# Altium schematic sheet [04] - SX1276.SchDoc("[04] - SX1276")
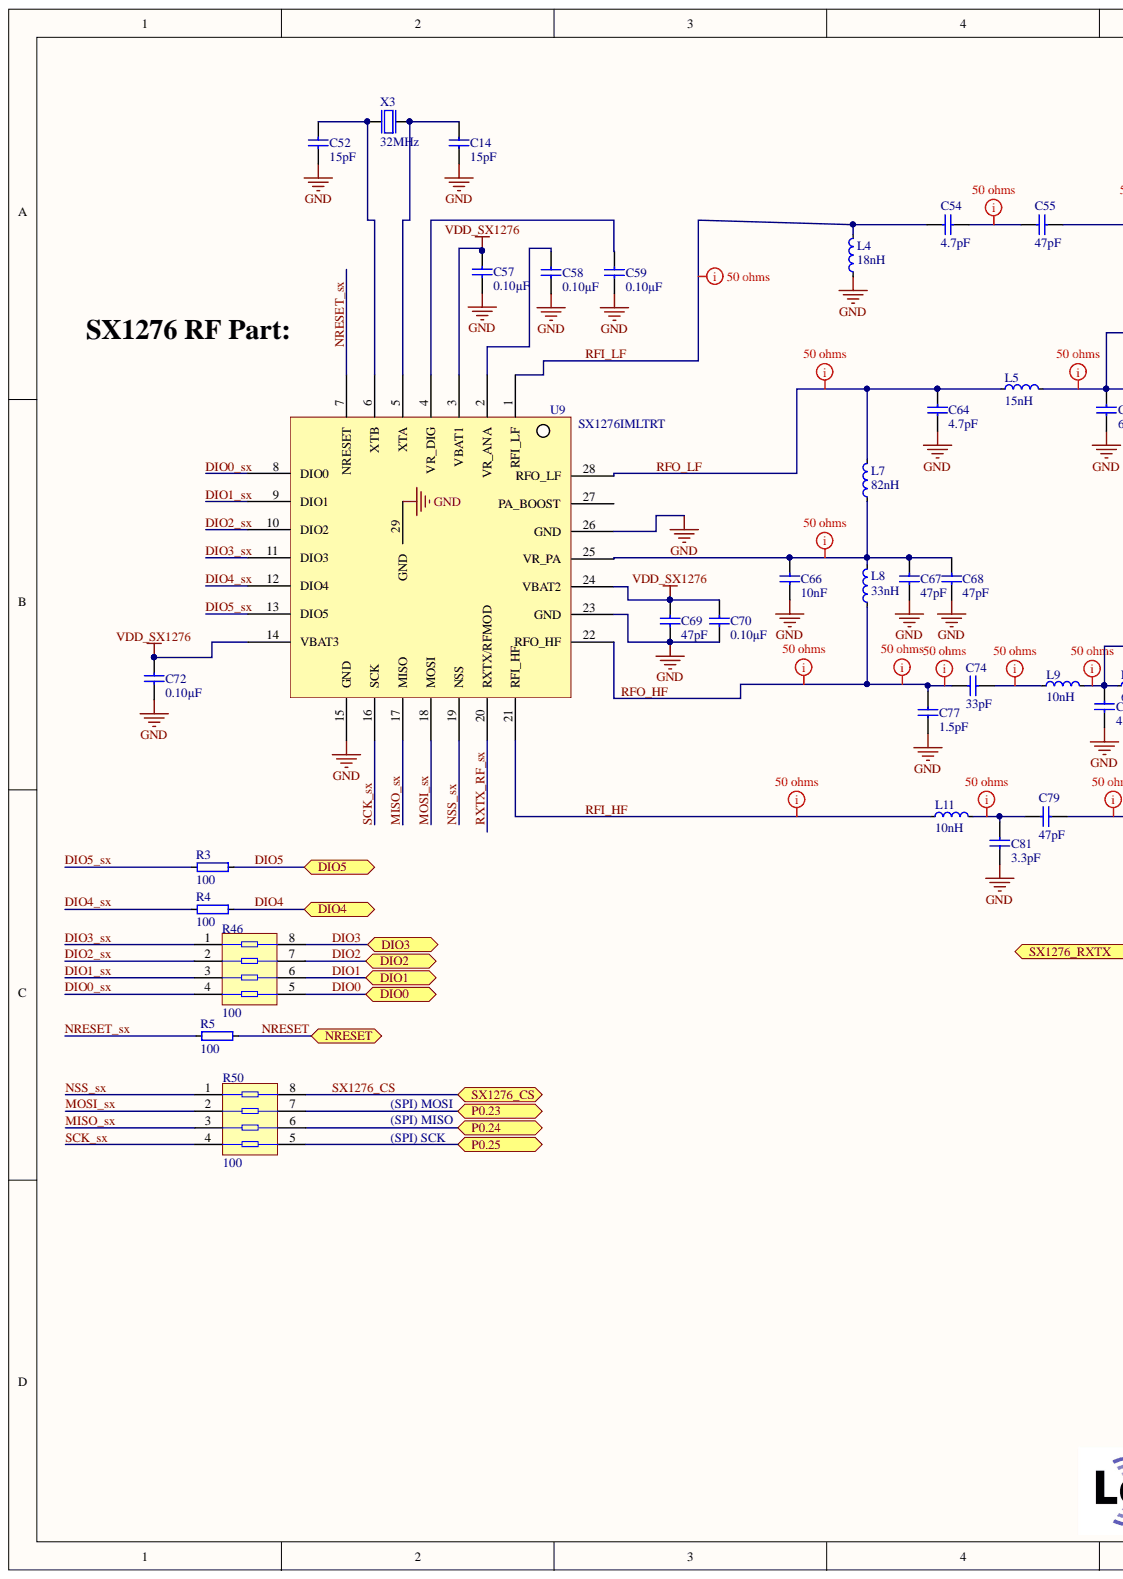
[diagram p0: [04] - SX1276.SchDoc, left]
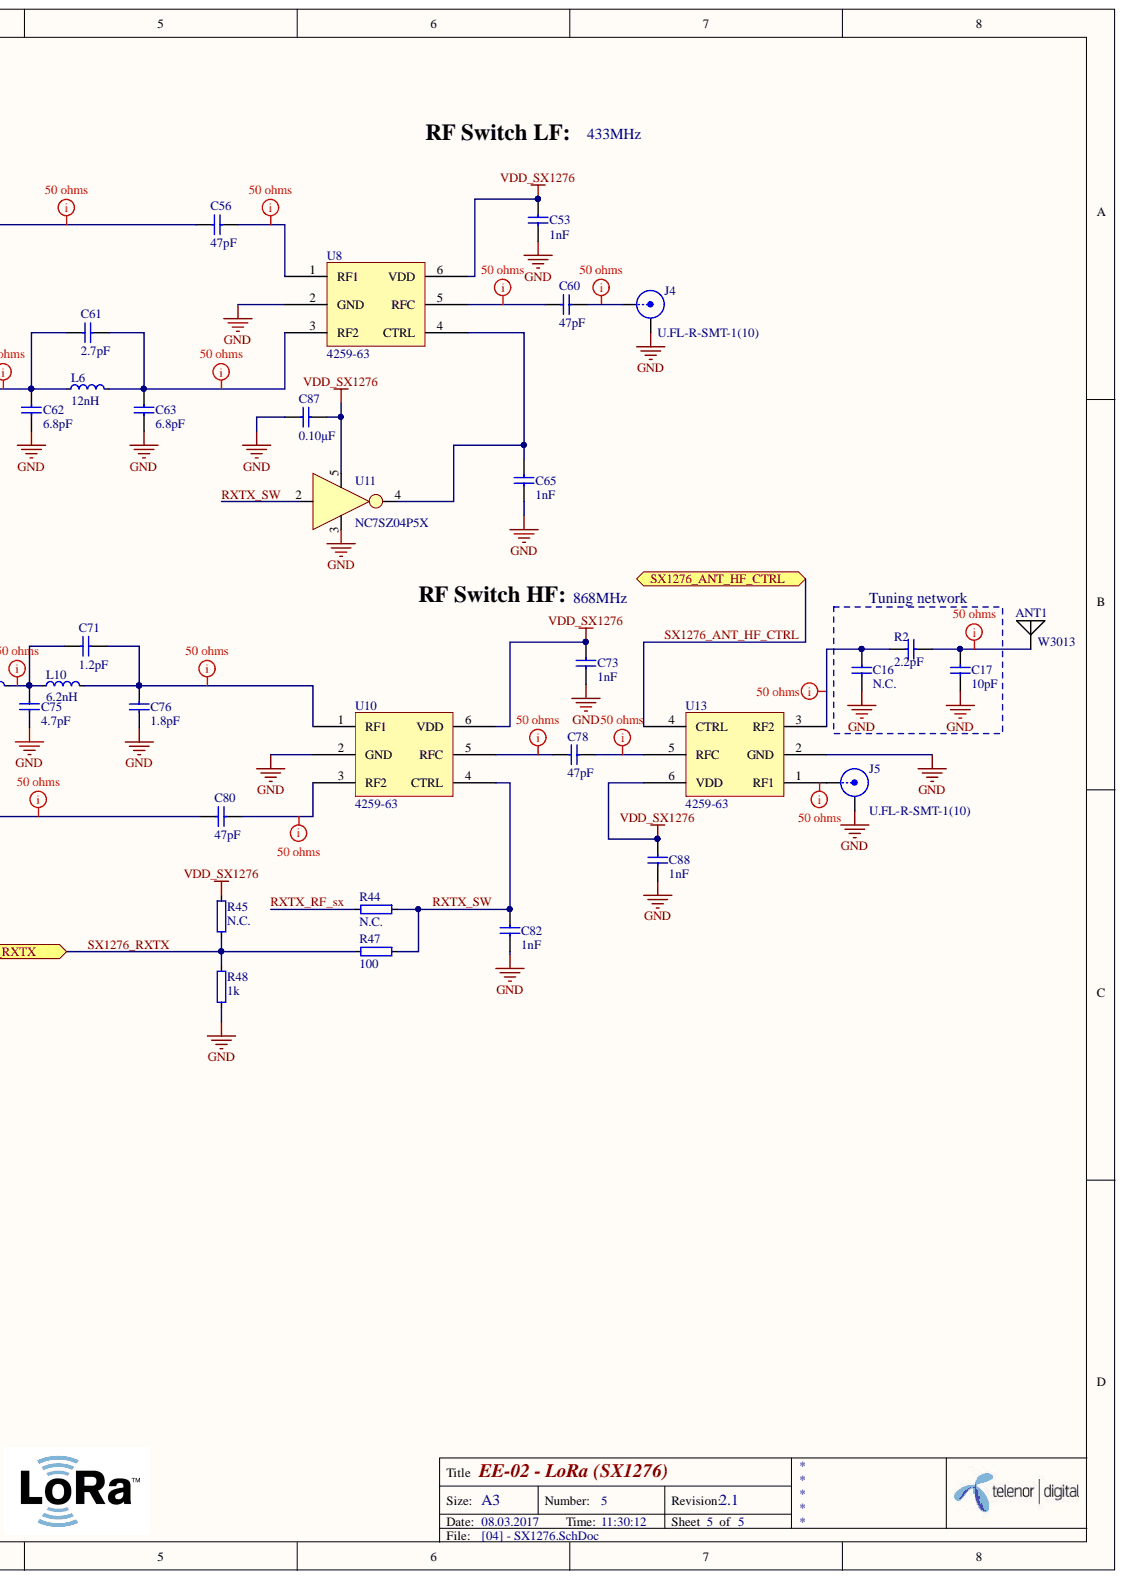
[diagram p1: [04] - SX1276.SchDoc, right]

## Parts
ANT1  W3013  [PULSE_W3013_R]
C14  15pF  [CAPC0603X03L]
C16  N.C.  [CAPC0603X03L]  (no BOM)
C17  10pF  [CAPC0603X03L]
C52  15pF  [CAPC0603X03L]
C53  1nF  [CAPC0603X03L]
C54  4.7pF  [CAPC0603X03L]
C55  47pF  [CAPC0603X03L]
C56  47pF  [CAPC0603X03L]
C57  0.10µF  [CAPC0603X03L]
C58  0.10µF  [CAPC0603X03L]
C59  0.10µF  [CAPC0603X03L]
C60  47pF  [CAPC0603X03L]
C61  2.7pF  [CAPC0603X03L]
C62  6.8pF  [CAPC0603X03L]
C63  6.8pF  [CAPC0603X03L]
C64  4.7pF  [CAPC0603X03L]
C65  1nF  [CAPC0603X03L]
C66  10nF  [CAPC0603X03L]
C67  47pF  [CAPC0603X03L]
C68  47pF  [CAPC0603X03L]
C69  47pF  [CAPC0603X03L]
C70  0.10µF  [CAPC0603X03L]
C71  1.2pF  [CAPC0603X03L]
C72  0.10µF  [CAPC0603X03L]
C73  1nF  [CAPC0603X03L]
C74  33pF  [CAPC0603X03L]
C75  4.7pF  [CAPC0603X03L]
C76  1.8pF  [CAPC0603X03L]
C77  1.5pF  [CAPC0603X03L]
C78  47pF  [CAPC0603X03L]
C79  47pF  [CAPC0603X03L]
C80  47pF  [CAPC0603X03L]
C81  3.3pF  [CAPC0603X03L]
C82  1nF  [CAPC0603X03L]
C87  0.10µF  [CAPC0603X03L]
C88  1nF  [CAPC0603X03L]
J4  U.FL-R-SMT-1(10)  [COAXIAL-UFL]
J5  U.FL-R-SMT-1(10)  [COAXIAL-UFL]
L4  18nH  [INDC1005X04L]
L5  15nH  [INDC1005X04L]
L6  12nH  [INDC1005X04L]
L7  82nH  [INDC1005X04L]
L8  33nH  [INDC1005X04L]
L9  10nH  [INDC1005X04L]
L10  6.2nH  [INDC1005X04L]
L11  10nH  [INDC1005X04L]
R2  2.2pF  [CAPC0603X03L]
R3  100  [RESC0603X03L]
R4  100  [RESC0603X03L]
R5  100  [RESC0603X03L]
R44  N.C.  [RESC0603X03LNC]
R45  N.C.  [RESC0603X03LNC]
R46  100  [EXB18V]
R47  100  [RESC0603X03L]
R48  1k  [RESC0603X03L]
R50  100  [EXB18V]
U8  4259-63  [SC70-6]
U9  SX1276IMLTRT  [vqfn28_6x6mm]
U10  4259-63  [SC70-6]
U11  NC7SZ04P5X  [SC70-5]
U13  4259-63  [SC70-6]
X3  32MHz  [nd2520da]

## Ports
DIO0, DIO1, DIO2, DIO3, DIO4, DIO5, NRESET, P0.23, P0.24, P0.25, SX1276_ANT_HF_CTRL, SX1276_CS, SX1276_RXTX

## Nets
GND: ANT1.2 ANT1.3 C14.1 C16.1 C17.1 C52.1 C53.1 C57.1 C58.1 C59.1 C62.1 C63.1 C64.1 C65.1 C66.1 C67.1 C68.1 C69.1 C70.1 C72.1 C73.1 C75.1 C76.1 C77.1 C81.1 C82.1 C87.1 C88.1 J4.2 J5.2 L4.1 R48.2 U8.2(GND) U9.15(GND) U9.23(GND) U9.26(GND) U9.29(GND) U10.2(GND) U11.3 U13.2(GND) X3.2 X3.4
VDD_SX1276: C53.2 C57.2 C69.2 C70.2 C72.2 C73.2 C87.2 C88.2 R45.2 U8.6(VDD) U9.3(VBAT1) U9.14(VBAT3) U9.24(VBAT2) U10.6(VDD) U11.5 U13.6(VDD)
DIO0: R46.5 ; port DIO0
DIO0_sx: R46.4 U9.8(DIO0)
DIO1: R46.6 ; port DIO1
DIO1_sx: R46.3 U9.9(DIO1)
DIO2: R46.7 ; port DIO2
DIO2_sx: R46.2 U9.10(DIO2)
DIO3: R46.8 ; port DIO3
DIO3_sx: R46.1 U9.11(DIO3)
DIO4: R4.2 ; port DIO4
DIO4_sx: R4.1 U9.12(DIO4)
DIO5: R3.2 ; port DIO5
DIO5_sx: R3.1 U9.13(DIO5)
MISO_sx: R50.3 U9.17(MISO)
MOSI_sx: R50.2 U9.18(MOSI)
NRESET: R5.2 ; port NRESET
NRESET_sx: R5.1 U9.7(NRESET)
NSS_sx: R50.1 U9.19(NSS)
P0.23: R50.7 ; port P0.23
P0.24: R50.6 ; port P0.24
P0.25: R50.5 ; port P0.25
RFI_HF: L11.1 U9.21(RFI_HF)
RFI_LF: C54.1 L4.2 U9.1(RFI_LF)
RFO_HF: C74.2 C77.2 L8.1 U9.22(RFO_HF)
RFO_LF: C64.2 L5.1 L7.2 U9.28(RFO_LF)
RXTX_RF_sx: R44.1 U9.20(RXTX/RFMOD)
RXTX_SW: C82.2 R44.2 R47.2 U10.4(CTRL) U11.2
SCK_sx: R50.4 U9.16(SCK)
SX1276_ANT_HF_CTRL: U13.4(CTRL) ; port SX1276_ANT_HF_CTRL
SX1276_CS: R50.8 ; port SX1276_CS
SX1276_RXTX: R45.1 R47.1 R48.1 ; port SX1276_RXTX
NetANT1_1: ANT1.1 C17.2 R2.2
NetC14_2: C14.2 U9.5(XTA) X3.3
NetC16_2: C16.2 R2.1 U13.3(RF2)
NetC52_2: C52.2 U9.6(XTB) X3.1
NetC54_2: C54.2 C55.2
NetC55_1: C55.1 C56.2
NetC56_1: C56.1 U8.1(RF1)
NetC58_2: C58.2 U9.2(VR_ANA)
NetC59_2: C59.2 U9.4(VR_DIG)
NetC60_1: C60.1 J4.1
NetC60_2: C60.2 U8.5(RFC)
NetC61_1: C61.1 C62.2 L5.2 L6.1
NetC61_2: C61.2 C63.2 L6.2 U8.3(RF2)
NetC65_2: C65.2 U8.4(CTRL) U11.4
NetC66_2: C66.2 C67.2 C68.2 L7.1 L8.2 U9.25(VR_PA)
NetC71_1: C71.1 C75.2 L9.2 L10.1
NetC71_2: C71.2 C76.2 L10.2 U10.1(RF1)
NetC74_1: C74.1 L9.1
NetC78_1: C78.1 U13.5(RFC)
NetC78_2: C78.2 U10.5(RFC)
NetC79_1: C79.1 C80.2
NetC79_2: C79.2 C81.2 L11.2
NetC80_1: C80.1 U10.3(RF2)
NetJ5_1: J5.1 U13.1(RF1)
N00119:

Not connected: U9 27(PA_BOOST)

## Library data of the parts
ANT1 = W3013: ANTENNA CERAMIC ISM 868MHZ; Manufacturer: Pulse Electronics Corporation; Return Loss: -10dB; Number of Bands: 1; Gain: 1.4dBi; Frequency Range: 868MHz ~ 870MHz; Frequency Group: UHF (300 MHz ~ 1 GHz); Antenna Type: Chip; VSWR: -; Value: W3013; Termination: Solder; Supplier Part Number 1: 553-1677-6-ND; Supplier 1: Digi-Key; Stock 1: 2216; RoHS: RoHS Compliant; Pricing 1: 1=1.75, 10=1.584, 25=1.4192, 100=1.276, 250=1.133 (USD); Power - Max: -; Part Status: Active; Packaging: Digi-Reel®; Mounting Type: Surface Mount; Manufacturer Part Number: W3013; Ingress Protection: -; Height (Max): 0.157" (4.00mm); Frequency (Center/Band): 868MHz; Features: -; ComponentLink1URL: http://productfinder.pulseeng.com/products/datasheets/W3013.pdf; ComponentLink1Description: http://productfinder.pulseeng.com/products/datasheets/W3013.pdf; Component Kind: Standard; Category: RF/IF and RFID; Applications: -; Library Name: Skunkworks Library.IntLib
C14 C52 = GRM0335C1H150GA01D: CAP CER 15PF 50V NP0 0201; Manufacturer: Murata Electronics North America; Tolerance: ±2%; Temperature Coefficient: C0G, NP0; Voltage - Rated: 50V; Value: 15pF; Thickness (Max): 0.013" (0.33mm); Supplier Part Number 1: 490-11309-6-ND; Supplier 1: Digi-Key; Stock 1: 750; Size / Dimension: 0.024" L x 0.012" W (0.60mm x 0.30mm); RoHS: RoHS Compliant; Ratings: -; Pricing 1: 1=0.1, 10=0.014, 50=0.0078, 100=0.0066, 250=0.0054, 500=0.00462, 1000=0.0036, 5000=0.003 (USD); Part Status: Active; Packaging: Digi-Reel®; Package / Case: 0201 (0603 Metric); Operating Temperature: -55°C ~ 125°C; Mounting Type: Surface Mount, MLCC; Manufacturer Part Number: GRM0335C1H150GA01D; Lead Style: -; Lead Spacing: -; Height - Seated (Max): -; Features: -; ComponentLink1URL: http://www.murata.com/~/media/webrenewal/support/library/catalog/products/capacitor/mlcc/c02e.pdf; ComponentLink1Description: http://www.murata.com/~/media/webrenewal/support/library/catalog/products/capacitor/mlcc/c02e.pdf; Component Kind: Standard; Category: Capacitors; Capacitance: 15pF; Applications: General Purpose; Library Name: Skunkworks Library.IntLib
C16 = CAP_0201-NC: Capacitor, 0201, N.C.; Part Number: C0201-NC; Artikkelnr Simpro: N.A.; Value: N.C.; Published: 2016-11-04; Pin Count: 2; LatestRevisionDate: 2016-11-04; Footprint Doc: 0201; Artikkelnr Noca: N.A.; Publisher: Telenor Digital; Library Name: Skunkworks Library.IntLib
C17 = 250R05L100GV4T: CAP CER 10PF 25V NP0 0201; Manufacturer: Johanson Technology Inc.; Tolerance: ±2%; Temperature Coefficient: C0G, NP0; Voltage - Rated: 25V; Value: 10pF; Thickness (Max): 0.013" (0.33mm); Supplier Part Number 1: 712-1204-6-ND; Supplier 1: Digi-Key; Stock 1: 18246; Size / Dimension: 0.024" L x 0.012" W (0.60mm x 0.30mm); RoHS: RoHS Compliant; Ratings: -; Pricing 1: 1=0.23, 10=0.16, 25=0.1172, 50=0.0958, 100=0.0809, 250=0.06596, 500=0.05532, 1000=0.04894, 5000=0.04256 (USD); Part Status: Active; Packaging: Digi-Reel®; Package / Case: 0201 (0603 Metric); Operating Temperature: -55°C ~ 125°C; Mounting Type: Surface Mount, MLCC; Manufacturer Part Number: 250R05L100GV4T; Lead Style: -; Lead Spacing: -; Height - Seated (Max): -; Features: High Q, Low Loss; ComponentLink1URL: http://www.johansontechnology.com/downloads/JTI-CAT-MLCC-HighQ.pdf; ComponentLink1Description: http://www.johansontechnology.com/downloads/JTI-CAT-MLCC-HighQ.pdf; Component Kind: Standard; Category: Capacitors; Capacitance: 10pF; Applications: RF, Microwave, High Frequency; Library Name: Skunkworks Library.IntLib
C53 C65 C73 C82 C88 = GRM033R71H102KA12D: CAP CER 1NF 50V X7R 0201; Manufacturer: Murata Electronics North America; Tolerance: ±10%; Voltage - Rated: 50V; Value: 1nF; Thickness (Max): 0.013" (0.33mm); Temperature Coefficient: X7R; Supplier Part Number 1: 490-10682-6-ND; Supplier 1: Digi-Key; Stock 1: 132650; Size / Dimension: 0.024" L x 0.012" W (0.60mm x 0.30mm); RoHS: RoHS Compliant; Ratings: -; Pricing 1: 1=0.1, 10=0.012, 50=0.0066, 100=0.0055, 250=0.00452, 500=0.00386, 1000=0.003, 5000=0.0025 (USD); Part Status: Active; Packaging: Digi-Reel®; Package / Case: 0201 (0603 Metric); Operating Temperature: -55°C ~ 125°C; Mounting Type: Surface Mount, MLCC; Manufacturer Part Number: GRM033R71H102KA12D; Lead Style: -; Lead Spacing: -; Height - Seated (Max): -; Features: -; ComponentLink1URL: http://www.murata.com/~/media/webrenewal/support/library/catalog/products/capacitor/mlcc/c02e.pdf; ComponentLink1Description: http://www.murata.com/~/media/webrenewal/support/library/catalog/products/capacitor/mlcc/c02e.pdf; Component Kind: Standard; Category: Capacitors; Capacitance: 1nF; Applications: General Purpose; Library Name: Skunkworks Library.IntLib
C54 C64 C75 = GRM0335C1H4R7BA01D: CAP CER 4.7PF 50V NP0 0201; Manufacturer: Murata Electronics North America; Tolerance: ±0.1pF; Temperature Coefficient: C0G, NP0; Voltage - Rated: 50V; Value: 4.7pF; Thickness (Max): 0.013" (0.33mm); Supplier Part Number 1: 490-11332-6-ND; Supplier 1: Digi-Key; Stock 1: 70150; Size / Dimension: 0.024" L x 0.012" W (0.60mm x 0.30mm); RoHS: RoHS Compliant; Ratings: -; Pricing 1: 1=0.1, 10=0.011, 50=0.0058, 100=0.005, 250=0.00404, 500=0.00346, 1000=0.0027, 5000=0.00225 (USD); Part Status: Active; Packaging: Digi-Reel®; Package / Case: 0201 (0603 Metric); Operating Temperature: -55°C ~ 125°C; Mounting Type: Surface Mount, MLCC; Manufacturer Part Number: GRM0335C1H4R7BA01D; Lead Style: -; Lead Spacing: -; Height - Seated (Max): -; Features: -; ComponentLink1URL: http://www.murata.com/~/media/webrenewal/support/library/catalog/products/capacitor/mlcc/c02e.pdf; ComponentLink1Description: http://www.murata.com/~/media/webrenewal/support/library/catalog/products/capacitor/mlcc/c02e.pdf; Component Kind: Standard; Category: Capacitors; Capacitance: 4.7pF; Applications: General Purpose; Library Name: Skunkworks Library.IntLib
C55 C56 C60 C67-C69 C78-C80 = GRM0335C1H470JA01D: CAP CER 47PF 50V NP0 0201; Manufacturer: Murata Electronics North America; Tolerance: ±5%; Temperature Coefficient: C0G, NP0; Voltage - Rated: 50V; Value: 47pF; Supplier Part Number 1: 490-6129-6-ND; Supplier 1: Digi-Key; Stock 1: 174645; Size / Dimension: 0.024" L x 0.012" W (0.60mm x 0.30mm); RoHS: RoHS Compliant; Ratings: -; Pricing 1: 1=0.1, 10=0.011, 50=0.0058, 100=0.005, 250=0.00404, 500=0.00346, 1000=0.0027, 5000=0.00225 (USD); Part Status: Active; Packaging: Digi-Reel®; Package / Case: 0201 (0603 Metric); Operating Temperature: -55°C ~ 125°C; Mounting Type: Surface Mount, MLCC; Manufacturer Part Number: GRM0335C1H470JA01D; Lead Style: -; Lead Spacing: -; Height - Seated (Max): -; Features: -; ComponentLink1URL: http://www.murata.com/~/media/webrenewal/support/library/catalog/products/capacitor/mlcc/c02e.pdf; ComponentLink1Description: http://www.murata.com/~/media/webrenewal/support/library/catalog/products/capacitor/mlcc/c02e.pdf; Component Kind: Standard; Category: Capacitors; Capacitance: 47pF; Applications: General Purpose; Library Name: Skunkworks Library.IntLib
C57-C59 C70 C72 C87 = GRM033R61C104KE84D: CAP CER 0.1UF 16V X5R 0201; Manufacturer: Murata Electronics North America; Tolerance: ±10%; Voltage - Rated: 16V; Value: 0.10µF; Thickness (Max): 0.013" (0.33mm); Temperature Coefficient: X5R; Supplier Part Number 1: 490-9985-6-ND; Supplier 1: Digi-Key; Stock 1: 0; Size / Dimension: 0.024" L x 0.012" W (0.60mm x 0.30mm); RoHS: RoHS Compliant; Ratings: -; Pricing 1: 1=0.1, 10=0.025, 50=0.0136, 100=0.0116, 250=0.00944, 500=0.00808, 1000=0.0063, 5000=0.00525 (USD); Part Status: Active; Packaging: Digi-Reel®; Package / Case: 0201 (0603 Metric); Operating Temperature: -55°C ~ 85°C; Mounting Type: Surface Mount, MLCC; Manufacturer Part Number: GRM033R61C104KE84D; Lead Style: -; Lead Spacing: -; Height - Seated (Max): -; Features: Derating Recommended; ComponentLink1URL: http://www.murata.com/~/media/webrenewal/support/library/catalog/products/capacitor/mlcc/c02e.pdf; ComponentLink1Description: http://www.murata.com/~/media/webrenewal/support/library/catalog/products/capacitor/mlcc/c02e.pdf; Component Kind: Standard; Category: Capacitors; Capacitance: 0.10µF; Applications: General Purpose; Library Name: Skunkworks Library.IntLib
C61 = GRM0335C1H2R7BA01D: CAP CER 2.7PF 50V NP0 0201; Manufacturer: Murata Electronics North America; Tolerance: ±0.1pF; Temperature Coefficient: C0G, NP0; Voltage - Rated: 50V; Value: 2.7pF; Thickness (Max): 0.013" (0.33mm); Supplier Part Number 1: 490-11322-6-ND; Supplier 1: Digi-Key; Stock 1: 26213; Size / Dimension: 0.024" L x 0.012" W (0.60mm x 0.30mm); RoHS: RoHS Compliant; Ratings: -; Pricing 1: 1=0.1, 10=0.014, 50=0.0078, 100=0.0066, 250=0.0054, 500=0.00462, 1000=0.0036, 5000=0.003 (USD); Part Status: Active; Packaging: Digi-Reel®; Package / Case: 0201 (0603 Metric); Operating Temperature: -55°C ~ 125°C; Mounting Type: Surface Mount, MLCC; Manufacturer Part Number: GRM0335C1H2R7BA01D; Lead Style: -; Lead Spacing: -; Height - Seated (Max): -; Features: -; ComponentLink1URL: http://www.murata.com/~/media/webrenewal/support/library/catalog/products/capacitor/mlcc/c02e.pdf; ComponentLink1Description: http://www.murata.com/~/media/webrenewal/support/library/catalog/products/capacitor/mlcc/c02e.pdf; Component Kind: Standard; Category: Capacitors; Capacitance: 2.7pF; Applications: General Purpose; Library Name: Skunkworks Library.IntLib
C62 C63 = GRM0335C1H6R8CA01D: CAP CER 6.8PF 50V NP0 0201; Manufacturer: Murata Electronics North America; Tolerance: ±0.25pF; Temperature Coefficient: C0G, NP0; Voltage - Rated: 50V; Value: 6.8pF; Thickness (Max): 0.013" (0.33mm); Supplier Part Number 1: 490-11341-6-ND; Supplier 1: Digi-Key; Stock 1: 184512; Size / Dimension: 0.024" L x 0.012" W (0.60mm x 0.30mm); RoHS: RoHS Compliant; Ratings: -; Pricing 1: 1=0.1, 10=0.011, 50=0.0058, 100=0.005, 250=0.00404, 500=0.00346, 1000=0.0027, 5000=0.00225 (USD); Part Status: Active; Packaging: Digi-Reel®; Package / Case: 0201 (0603 Metric); Operating Temperature: -55°C ~ 125°C; Mounting Type: Surface Mount, MLCC; Manufacturer Part Number: GRM0335C1H6R8CA01D; Lead Style: -; Lead Spacing: -; Height - Seated (Max): -; Features: -; ComponentLink1URL: http://www.murata.com/~/media/webrenewal/support/library/catalog/products/capacitor/mlcc/c02e.pdf; ComponentLink1Description: http://www.murata.com/~/media/webrenewal/support/library/catalog/products/capacitor/mlcc/c02e.pdf; Component Kind: Standard; Category: Capacitors; Capacitance: 6.8pF; Applications: General Purpose; Library Name: Skunkworks Library.IntLib
C66 = GRM033R61E103KA12D: CAP CER 10NF 25V X5R 0201; Manufacturer: Murata Electronics North America; Tolerance: ±10%; Voltage - Rated: 25V; Value: 10nF; Thickness (Max): 0.013" (0.33mm); Temperature Coefficient: X5R; Supplier Part Number 1: 490-7194-6-ND; Supplier 1: Digi-Key; Stock 1: 1209439; Size / Dimension: 0.024" L x 0.012" W (0.60mm x 0.30mm); RoHS: RoHS Compliant; Ratings: -; Pricing 1: 1=0.1, 10=0.012, 50=0.0066, 100=0.0055, 250=0.00452, 500=0.00386, 1000=0.003, 5000=0.0025 (USD); Part Status: Active; Packaging: Digi-Reel®; Package / Case: 0201 (0603 Metric); Operating Temperature: -55°C ~ 85°C; Mounting Type: Surface Mount, MLCC; Manufacturer Part Number: GRM033R61E103KA12D; Lead Style: -; Lead Spacing: -; Height - Seated (Max): -; Features: Derating Recommended; ComponentLink1URL: http://www.murata.com/~/media/webrenewal/support/library/catalog/products/capacitor/mlcc/c02e.pdf; ComponentLink1Description: http://www.murata.com/~/media/webrenewal/support/library/catalog/products/capacitor/mlcc/c02e.pdf; Component Kind: Standard; Category: Capacitors; Capacitance: 10nF; Applications: General Purpose; Library Name: Skunkworks Library.IntLib
C71 = GRM0335C1H1R2BA01D: CAP CER 1.2PF 50V NP0 0201; Manufacturer: Murata Electronics North America; Tolerance: ±0.1pF; Temperature Coefficient: C0G, NP0; Voltage - Rated: 50V; Value: 1.2pF; Thickness (Max): 0.013" (0.33mm); Supplier Part Number 1: 490-9661-6-ND; Supplier 1: Digi-Key; Stock 1: 29460; Size / Dimension: 0.024" L x 0.012" W (0.60mm x 0.30mm); RoHS: RoHS Compliant; Ratings: -; Pricing 1: 1=0.1, 10=0.014, 50=0.0078, 100=0.0066, 250=0.0054, 500=0.00462, 1000=0.0036, 5000=0.003 (USD); Part Status: Active; Packaging: Digi-Reel®; Package / Case: 0201 (0603 Metric); Operating Temperature: -55°C ~ 125°C; Mounting Type: Surface Mount, MLCC; Manufacturer Part Number: GRM0335C1H1R2BA01D; Lead Style: -; Lead Spacing: -; Height - Seated (Max): -; Features: -; ComponentLink1URL: http://www.murata.com/~/media/webrenewal/support/library/catalog/products/capacitor/mlcc/c02e.pdf; ComponentLink1Description: http://www.murata.com/~/media/webrenewal/support/library/catalog/products/capacitor/mlcc/c02e.pdf; Component Kind: Standard; Category: Capacitors; Capacitance: 1.2pF; Applications: General Purpose; Library Name: Skunkworks Library.IntLib
C74 = GRM0335C1H330GA01D: CAP CER 33PF 50V NP0 0201; Manufacturer: Murata Electronics North America; Tolerance: ±2%; Temperature Coefficient: C0G, NP0; Voltage - Rated: 50V; Value: 33pF; Thickness (Max): 0.013" (0.33mm); Supplier Part Number 1: 490-11325-6-ND; Supplier 1: Digi-Key; Stock 1: 48655; Size / Dimension: 0.024" L x 0.012" W (0.60mm x 0.30mm); RoHS: RoHS Compliant; Ratings: -; Pricing 1: 1=0.1, 10=0.014, 50=0.0078, 100=0.0066, 250=0.0054, 500=0.00462, 1000=0.0036, 5000=0.003 (USD); Part Status: Active; Packaging: Digi-Reel®; Package / Case: 0201 (0603 Metric); Operating Temperature: -55°C ~ 125°C; Mounting Type: Surface Mount, MLCC; Manufacturer Part Number: GRM0335C1H330GA01D; Lead Style: -; Lead Spacing: -; Height - Seated (Max): -; Features: -; ComponentLink1URL: http://www.murata.com/~/media/webrenewal/support/library/catalog/products/capacitor/mlcc/c02e.pdf; ComponentLink1Description: http://www.murata.com/~/media/webrenewal/support/library/catalog/products/capacitor/mlcc/c02e.pdf; Component Kind: Standard; Category: Capacitors; Capacitance: 33pF; Applications: General Purpose; Library Name: Skunkworks Library.IntLib
C76 = GRM0335C1H1R8BA01D: CAP CER 1.8PF 50V NP0 0201; Manufacturer: Murata Electronics North America; Tolerance: ±0.1pF; Temperature Coefficient: C0G, NP0; Voltage - Rated: 50V; Value: 1.8pF; Thickness (Max): 0.013" (0.33mm); Supplier Part Number 1: 490-11313-6-ND; Supplier 1: Digi-Key; Stock 1: 20450; Size / Dimension: 0.024" L x 0.012" W (0.60mm x 0.30mm); RoHS: RoHS Compliant; Ratings: -; Pricing 1: 1=0.1, 10=0.014, 50=0.0078, 100=0.0066, 250=0.0054, 500=0.00462, 1000=0.0036, 5000=0.003 (USD); Part Status: Active; Packaging: Digi-Reel®; Package / Case: 0201 (0603 Metric); Operating Temperature: -55°C ~ 125°C; Mounting Type: Surface Mount, MLCC; Manufacturer Part Number: GRM0335C1H1R8BA01D; Lead Style: -; Lead Spacing: -; Height - Seated (Max): -; Features: -; ComponentLink1URL: http://www.murata.com/~/media/webrenewal/support/library/catalog/products/capacitor/mlcc/c02e.pdf; ComponentLink1Description: http://www.murata.com/~/media/webrenewal/support/library/catalog/products/capacitor/mlcc/c02e.pdf; Component Kind: Standard; Category: Capacitors; Capacitance: 1.8pF; Applications: General Purpose; Library Name: Skunkworks Library.IntLib
C77 = GRM0335C1H1R5BA01D: CAP CER 1.5PF 50V NP0 0201; Manufacturer: Murata Electronics North America; Tolerance: ±0.1pF; Temperature Coefficient: C0G, NP0; Voltage - Rated: 50V; Value: 1.5pF; Thickness (Max): 0.013" (0.33mm); Supplier Part Number 1: 490-11311-6-ND; Supplier 1: Digi-Key; Stock 1: 40910; Size / Dimension: 0.024" L x 0.012" W (0.60mm x 0.30mm); RoHS: RoHS Compliant; Ratings: -; Pricing 1: 1=0.1, 10=0.014, 50=0.0078, 100=0.0066, 250=0.0054, 500=0.00462, 1000=0.0036, 5000=0.003 (USD); Part Status: Active; Packaging: Digi-Reel®; Package / Case: 0201 (0603 Metric); Operating Temperature: -55°C ~ 125°C; Mounting Type: Surface Mount, MLCC; Manufacturer Part Number: GRM0335C1H1R5BA01D; Lead Style: -; Lead Spacing: -; Height - Seated (Max): -; Features: -; ComponentLink1URL: http://www.murata.com/~/media/webrenewal/support/library/catalog/products/capacitor/mlcc/c02e.pdf; ComponentLink1Description: http://www.murata.com/~/media/webrenewal/support/library/catalog/products/capacitor/mlcc/c02e.pdf; Component Kind: Standard; Category: Capacitors; Capacitance: 1.5pF; Applications: General Purpose; Library Name: Skunkworks Library.IntLib
C81 = GRM0335C1H3R3BA01D: CAP CER 3.3PF 50V NP0 0201; Manufacturer: Murata Electronics North America; Tolerance: ±0.1pF; Temperature Coefficient: C0G, NP0; Voltage - Rated: 50V; Value: 3.3pF; Thickness (Max): 0.013" (0.33mm); Supplier Part Number 1: 490-11328-6-ND; Supplier 1: Digi-Key; Stock 1: 48664; Size / Dimension: 0.024" L x 0.012" W (0.60mm x 0.30mm); RoHS: RoHS Compliant; Ratings: -; Pricing 1: 1=0.1, 10=0.014, 50=0.0078, 100=0.0066, 250=0.0054, 500=0.00462, 1000=0.0036, 5000=0.003 (USD); Part Status: Active; Packaging: Digi-Reel®; Package / Case: 0201 (0603 Metric); Operating Temperature: -55°C ~ 125°C; Mounting Type: Surface Mount, MLCC; Manufacturer Part Number: GRM0335C1H3R3BA01D; Lead Style: -; Lead Spacing: -; Height - Seated (Max): -; Features: -; ComponentLink1URL: http://www.murata.com/~/media/webrenewal/support/library/catalog/products/capacitor/mlcc/c02e.pdf; ComponentLink1Description: http://www.murata.com/~/media/webrenewal/support/library/catalog/products/capacitor/mlcc/c02e.pdf; Component Kind: Standard; Category: Capacitors; Capacitance: 3.3pF; Applications: General Purpose; Library Name: Skunkworks Library.IntLib
J4 J5 = U.FL-R-SMT-1(10): CONN UMC JACK STR 50 OHM SMD; Manufacturer: Hirose Electric Co Ltd; Shield Termination: Solder; Impedance: 50 Ohm; Frequency - Max: 6GHz; Dielectric Material: Liquid Crystal Polymer (LCP); Connector Type: Jack, Male Pin; Connector Style: U.FL; Center Contact Plating: Gold; Center Contact Material: Phosphor Bronze; Body Finish: Silver; Voltage Rating: -; Supplier Part Number 1: H11891DKR-ND; Supplier 1: Digi-Key; Stock 1: 124618; RoHS: RoHS Compliant; Pricing 1: 1=1.15, 10=1.013, 25=0.9532, 50=0.9138, 100=0.8739, 250=0.79448, 500=0.71504, 1000=0.63559 (EUR); Packaging: Digi-Reel®; Operating Temperature: -40°C ~ 90°C; Mounting Type: Surface Mount; Manufacturer Part Number: U.FL-R-SMT-1(10); Ingress Protection: -; Housing Color: Silver; Features: -; Fastening Type: Snap-On; Contact Termination: Solder; ComponentLink1URL: http://media.digikey.com/pdf/Data%20Sheets/Hirose%20PDFs/UFL%20Series.pdf; ComponentLink1Description: http://media.digikey.com/pdf/Data%20Sheets/Hirose%20PDFs/UFL%20Series.pdf; Component Kind: Standard; Category: Connectors, Interconnects; Cable Group: -; Body Material: Phosphor Bronze; Library Name: Skunkworks Library.IntLib
L4 = LQG15HS18NJ02D: FIXED IND 18NH 300MA 360 MOHM; Manufacturer: Murata Electronics North America; Type: Multilayer; Tolerance: ±5%; Shielding: Unshielded; Q @ Freq: 8 @ 100MHz; Material - Core: Air; Frequency - Test: 100MHz; Frequency - Self Resonant: 2.2GHz; DC Resistance (DCR): 360 mOhm Max; Value: 18nH; Supplier Part Number 1: 490-2626-6-ND; Supplier 1: Digi-Key; Stock 1: 165090; Size / Dimension: 0.039" L x 0.020" W (1.00mm x 0.50mm); RoHS: RoHS Compliant; Ratings: -; Pricing 1: 1=0.09, 10=0.052, 25=0.0464, 50=0.0402, 100=0.0361, 250=0.03352, 500=0.03092, 750=0.02937, 1000=0.02679 (EUR); Packaging: Digi-Reel®; Package / Case: 0402 (1005 Metric); Operating Temperature: -55°C ~ 125°C; Mounting Type: Surface Mount; Manufacturer Part Number: LQG15HS18NJ02D; Inductance: 18nH; Height - Seated (Max): 0.022" (0.55mm); Current Rating: 300mA; Current - Saturation: -; ComponentLink1URL: http://search.murata.co.jp/Ceramy/image/img/PDF/ENG/L0075S0083LQG15HS.pdf; ComponentLink1Description: http://search.murata.co.jp/Ceramy/image/img/PDF/ENG/L0075S0083LQG15HS.pdf; Component Kind: Standard; Category: Inductors, Coils, Chokes; Library Name: Skunkworks Library.IntLib
L5 = LQG15HS15NJ02D: FIXED IND 15NH 300MA 320 MOHM; Manufacturer: Murata Electronics North America; Type: Multilayer; Tolerance: ±5%; Shielding: Unshielded; Q @ Freq: 8 @ 100MHz; Material - Core: Air; Frequency - Test: 100MHz; Frequency - Self Resonant: 2.5GHz; DC Resistance (DCR): 320 mOhm Max; Value: 15nH; Supplier Part Number 1: 490-2625-6-ND; Supplier 1: Digi-Key; Stock 1: 47142; Size / Dimension: 0.039" L x 0.020" W (1.00mm x 0.50mm); RoHS: RoHS Compliant; Ratings: -; Pricing 1: 1=0.09, 10=0.052, 25=0.0464, 50=0.0402, 100=0.0361, 250=0.03352, 500=0.03092, 750=0.02937, 1000=0.02679 (EUR); Packaging: Digi-Reel®; Package / Case: 0402 (1005 Metric); Operating Temperature: -55°C ~ 125°C; Mounting Type: Surface Mount; Manufacturer Part Number: LQG15HS15NJ02D; Inductance: 15nH; Height - Seated (Max): 0.022" (0.55mm); Current Rating: 300mA; Current - Saturation: -; ComponentLink1URL: http://search.murata.co.jp/Ceramy/image/img/PDF/ENG/L0075S0083LQG15HS.pdf; ComponentLink1Description: http://search.murata.co.jp/Ceramy/image/img/PDF/ENG/L0075S0083LQG15HS.pdf; Component Kind: Standard; Category: Inductors, Coils, Chokes; Library Name: Skunkworks Library.IntLib
L6 = LQG15HS12NJ02D: FIXED IND 12NH 300MA 280 MOHM; Manufacturer: Murata Electronics North America; Type: Multilayer; Tolerance: ±5%; Shielding: Unshielded; Q @ Freq: 8 @ 100MHz; Material - Core: Air; Frequency - Test: 100MHz; Frequency - Self Resonant: 3GHz; DC Resistance (DCR): 280 mOhm Max; Value: 12nH; Supplier Part Number 1: 490-2624-6-ND; Supplier 1: Digi-Key; Stock 1: 77424; Size / Dimension: 0.039" L x 0.020" W (1.00mm x 0.50mm); RoHS: RoHS Compliant; Ratings: -; Pricing 1: 1=0.09, 10=0.052, 25=0.0464, 50=0.0402, 100=0.0361, 250=0.03352, 500=0.03092, 750=0.02937, 1000=0.02679 (EUR); Packaging: Digi-Reel®; Package / Case: 0402 (1005 Metric); Operating Temperature: -55°C ~ 125°C; Mounting Type: Surface Mount; Manufacturer Part Number: LQG15HS12NJ02D; Inductance: 12nH; Height - Seated (Max): 0.022" (0.55mm); Current Rating: 300mA; Current - Saturation: -; ComponentLink1URL: http://search.murata.co.jp/Ceramy/image/img/PDF/ENG/L0075S0083LQG15HS.pdf; ComponentLink1Description: http://search.murata.co.jp/Ceramy/image/img/PDF/ENG/L0075S0083LQG15HS.pdf; Component Kind: Standard; Category: Inductors, Coils, Chokes; Library Name: Skunkworks Library.IntLib
L7 = LQG15HS82NJ02D: FIXED IND 82NH 150MA 1.2 OHM SMD; Manufacturer: Murata Electronics North America; Type: Multilayer; Tolerance: ±5%; Shielding: Unshielded; Q @ Freq: 8 @ 100MHz; Material - Core: Air; Frequency - Test: 100MHz; Frequency - Self Resonant: 700MHz; DC Resistance (DCR): 1.2 Ohm Max; Value: 82nH; Supplier Part Number 1: 490-2634-6-ND; Supplier 1: Digi-Key; Stock 1: 28103; Size / Dimension: 0.039" L x 0.020" W (1.00mm x 0.50mm); RoHS: RoHS Compliant; Ratings: -; Pricing 1: 1=0.09, 10=0.061, 25=0.0548, 50=0.0474, 100=0.0425, 250=0.03948, 500=0.03646, 750=0.03464, 1000=0.0316 (EUR); Packaging: Digi-Reel®; Package / Case: 0402 (1005 Metric); Operating Temperature: -55°C ~ 125°C; Mounting Type: Surface Mount; Manufacturer Part Number: LQG15HS82NJ02D; Inductance: 82nH; Height - Seated (Max): 0.022" (0.55mm); Current Rating: 150mA; Current - Saturation: -; ComponentLink1URL: http://search.murata.co.jp/Ceramy/image/img/PDF/ENG/L0075S0083LQG15HS.pdf; ComponentLink1Description: http://search.murata.co.jp/Ceramy/image/img/PDF/ENG/L0075S0083LQG15HS.pdf; Component Kind: Standard; Category: Inductors, Coils, Chokes; Library Name: Skunkworks Library.IntLib
L8 = LQW15AN33NJ00D: FIXED IND 33NH 260MA 630 MOHM; Manufacturer: Murata Electronics North America; Type: Wirewound; Tolerance: ±5%; Shielding: Unshielded; Q @ Freq: 25 @ 250MHz; Material - Core: Non-Magnetic; Frequency - Test: 100MHz; Frequency - Self Resonant: 3.2GHz; DC Resistance (DCR): 630 mOhm Max; Value: 33nH; Supplier Part Number 1: 490-1152-6-ND; Supplier 1: Digi-Key; Stock 1: 51716; Size / Dimension: 0.039" L x 0.020" W (1.00mm x 0.50mm); RoHS: RoHS Compliant; Ratings: -; Pricing 1: 1=0.15, 10=0.132, 25=0.1192, 50=0.103, 100=0.0925, 250=0.08588, 500=0.07928, 750=0.07532, 1000=0.0687 (EUR); Packaging: Digi-Reel®; Package / Case: 0402 (1005 Metric); Operating Temperature: -55°C ~ 125°C; Mounting Type: Surface Mount; Manufacturer Part Number: LQW15AN33NJ00D; Inductance: 33nH; Height - Seated (Max): 0.024" (0.60mm); Current Rating: 260mA; Current - Saturation: -; ComponentLink1URL: http://search.murata.co.jp/Ceramy/image/img/PDF/ENG/L0075S0080LQW15A_00.pdf; ComponentLink1Description: http://search.murata.co.jp/Ceramy/image/img/PDF/ENG/L0075S0080LQW15A_00.pdf; Component Kind: Standard; Category: Inductors, Coils, Chokes; Library Name: Skunkworks Library.IntLib
L9 L11 = LQG15HS10NJ02D: FIXED IND 10NH 300MA 260 MOHM; Manufacturer: Murata Electronics North America; Type: Multilayer; Tolerance: ±5%; Shielding: Unshielded; Q @ Freq: 8 @ 100MHz; Material - Core: Air; Frequency - Test: 100MHz; Frequency - Self Resonant: 3.4GHz; DC Resistance (DCR): 260 mOhm Max; Value: 10nH; Supplier Part Number 1: 490-2623-6-ND; Supplier 1: Digi-Key; Stock 1: 110764; Size / Dimension: 0.039" L x 0.020" W (1.00mm x 0.50mm); RoHS: RoHS Compliant; Ratings: -; Pricing 1: 1=0.09, 10=0.052, 25=0.0464, 50=0.0402, 100=0.0361, 250=0.03352, 500=0.03092, 750=0.02937, 1000=0.02679 (EUR); Packaging: Digi-Reel®; Package / Case: 0402 (1005 Metric); Operating Temperature: -55°C ~ 125°C; Mounting Type: Surface Mount; Manufacturer Part Number: LQG15HS10NJ02D; Inductance: 10nH; Height - Seated (Max): 0.022" (0.55mm); Current Rating: 300mA; Current - Saturation: -; ComponentLink1URL: http://search.murata.co.jp/Ceramy/image/img/PDF/ENG/L0075S0083LQG15HS.pdf; ComponentLink1Description: http://search.murata.co.jp/Ceramy/image/img/PDF/ENG/L0075S0083LQG15HS.pdf; Component Kind: Standard; Category: Inductors, Coils, Chokes; Library Name: Skunkworks Library.IntLib
L10 = LQG15HS6N2S02D: FIXED IND 6.2NH 300MA 220 MOHM; Manufacturer: Murata Electronics North America; Type: Multilayer; Tolerance: ±0.3nH; Shielding: Unshielded; Q @ Freq: 8 @ 100MHz; Material - Core: Air; Frequency - Test: 100MHz; Frequency - Self Resonant: 4.5GHz; DC Resistance (DCR): 220 mOhm Max; Value: 6.2nH; Supplier Part Number 1: 490-2620-6-ND; Supplier 1: Digi-Key; Stock 1: 52721; Size / Dimension: 0.039" L x 0.020" W (1.00mm x 0.50mm); RoHS: RoHS Compliant; Ratings: -; Pricing 1: 1=0.09, 10=0.052, 25=0.0464, 50=0.0402, 100=0.0361, 250=0.03352, 500=0.03092, 750=0.02937, 1000=0.02679 (EUR); Packaging: Digi-Reel®; Package / Case: 0402 (1005 Metric); Operating Temperature: -55°C ~ 125°C; Mounting Type: Surface Mount; Manufacturer Part Number: LQG15HS6N2S02D; Inductance: 6.2nH; Height - Seated (Max): 0.022" (0.55mm); Current Rating: 300mA; Current - Saturation: -; ComponentLink1URL: http://search.murata.co.jp/Ceramy/image/img/PDF/ENG/L0075S0083LQG15HS.pdf; ComponentLink1Description: http://search.murata.co.jp/Ceramy/image/img/PDF/ENG/L0075S0083LQG15HS.pdf; Component Kind: Standard; Category: Inductors, Coils, Chokes; Library Name: Skunkworks Library.IntLib
R2 = 250R05L2R2BV4T: CAP CER 2.2PF 25V NP0 0201; Manufacturer: Johanson Technology Inc.; Tolerance: ±0.1pF; Temperature Coefficient: C0G, NP0; Voltage - Rated: 25V; Value: 2.2pF; Thickness (Max): 0.013" (0.33mm); Supplier Part Number 1: 712-1188-6-ND; Supplier 1: Digi-Key; Stock 1: 75089; Size / Dimension: 0.024" L x 0.012" W (0.60mm x 0.30mm); RoHS: RoHS Compliant; Ratings: -; Pricing 1: 1=0.23, 10=0.16, 25=0.1172, 50=0.0958, 100=0.0809, 250=0.06596, 500=0.05532, 1000=0.04894, 5000=0.04256 (USD); Part Status: Active; Packaging: Digi-Reel®; Package / Case: 0201 (0603 Metric); Operating Temperature: -55°C ~ 125°C; Mounting Type: Surface Mount, MLCC; Manufacturer Part Number: 250R05L2R2BV4T; Lead Style: -; Lead Spacing: -; Height - Seated (Max): -; Features: High Q, Low Loss; ComponentLink1URL: http://www.johansontechnology.com/downloads/JTI-CAT-MLCC-HighQ.pdf; ComponentLink1Description: http://www.johansontechnology.com/downloads/JTI-CAT-MLCC-HighQ.pdf; Component Kind: Standard; Category: Capacitors; Capacitance: 2.2pF; Applications: RF, Microwave, High Frequency; Library Name: Skunkworks Library.IntLib
R3-R5 R47 = RC0201FR-07100RL: RES SMD 100 OHM 1% 1/20W 0201; Manufacturer: Yageo; Temperature Coefficient: ±200ppm/°C; Power (Watts): 0.05W, 1/20W; Value: 100; Tolerance: ±1%; Supplier Part Number 1: 311-100MDKR-ND; Supplier Device Package: 0201; Supplier 1: Digi-Key; Stock 1: 295829; Size / Dimension: 0.024" L x 0.012" W (0.60mm x 0.30mm); RoHS: RoHS Compliant; Resistance (Ohms): 100; Pricing 1: 1=0.1, 10=0.055, 25=0.0396, 100=0.0222, 250=0.01692, 500=0.01354, 1000=0.00997, 2500=0.00865, 5000=0.00715 (USD); Part Status: Active; Packaging: Digi-Reel®; Package / Case: 0201 (0603 Metric); Operating Temperature: -55°C ~ 125°C; Number of Terminations: 2; Manufacturer Part Number: RC0201FR-07100RL; Height - Seated (Max): 0.010" (0.26mm); Features: Moisture Resistant; Failure Rate: -; Composition: Thick Film; ComponentLink1URL: http://www.yageo.com/NewPortal/yageodocoutput?fileName=/pdf/R-Chip/PYu-RC_Group_51_RoHS_L_4.pdf; ComponentLink1Description: http://www.yageo.com/NewPortal/yageodocoutput?fileName=/pdf/R-Chip/PYu-RC_Group_51_RoHS_L_4.pdf; Component Kind: Standard; Category: Resistors; Library Name: Skunkworks Library.IntLib
R44 R45 = RES_0201: Not mounted; Part Number: R0201-N.C.; Note: Chip Res; Artikkelnr Simpro: N.A.; Value: N.C.; Published: 2009-05-08; Pin Count: 2; LatestRevisionDate: 2009-05-08; Footprint Doc: 0201; Component Kind: Standard; Artikkelnr Noca: N.A.; Publisher: Nordic Semiconductor; Library Name: Skunkworks Library.IntLib
R46 R50 = EXB-18V101JX: RES ARRAY 4 RES 100 OHM 0502; Manufacturer: Panasonic Electronic Components; Tolerance: ±5%; Temperature Coefficient: ±200ppm/°C; Power Per Element: 31mW; Number of Resistors: 4; Circuit Type: Isolated; Value: 100; Supplier Part Number 1: Y6101DKR-ND; Supplier Device Package: 0502; Supplier 1: Digi-Key; Stock 1: 27122; Size / Dimension: 0.055" L x 0.024" W (1.40mm x 0.60mm); RoHS: RoHS Compliant; Resistance (Ohms): 100; Pricing 1: 1=0.59, 50=0.2296, 100=0.1845, 250=0.14352, 500=0.10824, 1000=0.08364, 5000=0.06232 (USD); Part Status: Active; Packaging: Digi-Reel®; Package / Case: 0502 (1406 Metric), Long Side Terminals; Operating Temperature: -55°C ~ 125°C; Number of Pins: 8; Mounting Type: Surface Mount; Manufacturer Part Number: EXB-18V101JX; Height: 0.018" (0.45mm); ComponentLink1URL: https://industrial.panasonic.com/cdbs/www-data/pdf/AOC0000/AOC0000CE4.pdf; ComponentLink1Description: https://industrial.panasonic.com/cdbs/www-data/pdf/AOC0000/AOC0000CE4.pdf; Component Kind: Standard; Category: Resistors; Applications: -; Library Name: Skunkworks Library.IntLib
R48 = RC0201FR-071KL: RES SMD 1K OHM 1% 1/20W 0201; Manufacturer: Yageo; Temperature Coefficient: ±200ppm/°C; Power (Watts): 0.05W, 1/20W; Value: 1k; Tolerance: ±1%; Supplier Part Number 1: 311-1KMDKR-ND; Supplier Device Package: 0201; Supplier 1: Digi-Key; Stock 1: 243673; Size / Dimension: 0.024" L x 0.012" W (0.60mm x 0.30mm); RoHS: RoHS Compliant; Resistance (Ohms): 1k; Pricing 1: 1=0.1, 10=0.074, 25=0.054, 100=0.0303, 250=0.02312, 500=0.0185, 1000=0.01361, 2500=0.01182, 5000=0.00976 (USD); Part Status: Active; Packaging: Digi-Reel®; Package / Case: 0201 (0603 Metric); Operating Temperature: -55°C ~ 125°C; Number of Terminations: 2; Manufacturer Part Number: RC0201FR-071KL; Height - Seated (Max): 0.010" (0.26mm); Features: Moisture Resistant; Failure Rate: -; Composition: Thick Film; ComponentLink1URL: http://www.yageo.com/NewPortal/yageodocoutput?fileName=/pdf/R-Chip/PYu-RC_Group_51_RoHS_L_4.pdf; ComponentLink1Description: http://www.yageo.com/NewPortal/yageodocoutput?fileName=/pdf/R-Chip/PYu-RC_Group_51_RoHS_L_4.pdf; Component Kind: Standard; Category: Resistors; Library Name: Skunkworks Library.IntLib
U8 U10 U13 = 4259-63: IC RF SWITCH SPDT 50 OHM SC70-6; Manufacturer: Peregrine Semiconductor; Voltage - Supply: 1.8 V ~ 3.3 V; Topology: Reflective; RF Type: General Purpose; P1dB: 29dBm (typ) IP1dB; Isolation @ Frequency: 20dB @ 2GHz (typ); Insertion Loss @ Frequency: 0.5dB @ 2GHz; Impedance: 50 Ohm; IIP3: 55dBm (typ); Frequency - Upper: 3GHz; Frequency - Lower: 10MHz; Supplier Part Number 1: 1046-1011-6-ND; Supplier Device Package: SC-70-6; Supplier 1: Digi-Key; Stock 1: 190923; RoHS: RoHS Compliant; Pricing 1: 1=0.57, 101=0.45802, 1000=0.37874 (EUR); Packaging: Digi-Reel®; Package / Case: 6-TSSOP, SC-88, SOT-363; Operating Temperature: -40°C ~ 85°C; Manufacturer Part Number: 4259-63; Features: Single/Dual Line Control; ComponentLink1URL: http://www.psemi.com/pdf/datasheets/pe4259ds.pdf; ComponentLink1Description: http://www.psemi.com/pdf/datasheets/pe4259ds.pdf; Component Kind: Standard; Circuit: SPDT; Category: RF/IF and RFID; Library Name: Skunkworks Library.IntLib
U9 = SX1276IMLTRT: IC 137-1050MHX TXRX; Manufacturer: Semtech Corporation; Voltage - Supply: 1.8 V ~ 3.7 V; Serial Interfaces: SPI; Sensitivity: -137dBm; RF Family/Standard: General ISM < 1GHz; Power - Output: 20dBm; Modulation: FSK, GFSK, GMSK, LoRa™, MSK, OOK; Frequency: 137MHz ~ 1.02GHz; Data Rate (Max): 300kbps; Current - Transmitting: 28mA; Current - Receiving: 13mA; Type: TxRx Only; Supplier Part Number 1: SX1276IMLTRTDKR-ND; Supplier 1: Digi-Key; Stock 1: 8423; RoHS: RoHS Compliant; Protocol: -; Pricing 1: 1=7.42, 10=6.669, 25=6.0664, 100=5.4911, 250=5.03112, 500=4.59988, 1000=3.99615 (EUR); Packaging: Digi-Reel®; Package / Case: 28-VQFN Exposed Pad; Operating Temperature: -40°C ~ 85°C; Memory Size: -; Manufacturer Part Number: SX1276IMLTRT; GPIO: -; ComponentLink1URL: http://www.semtech.com/images/datasheet/sx1276.pdf; ComponentLink1Description: http://www.semtech.com/images/datasheet/sx1276.pdf; Component Kind: Standard; Category: RF/IF and RFID; Library Name: Skunkworks Library.IntLib
U11 = NC7SZ04P5X: IC INVERTER UHS SINGLE SC70-5; Manufacturer: Fairchild Semiconductor; Voltage - Supply: 1.65 V ~ 5.5 V; Number of Inputs: 1; Max Propagation Delay @ V, Max CL: 4.3ns @ 5V, 50pF; Current - Quiescent (Max): 2µA; Current - Output High, Low: 32mA, 32mA; Supplier Part Number 1: NC7SZ04P5XDKR-ND; Supplier Device Package: SC-70-5; Supplier 1: Digi-Key; Stock 1: 36767; RoHS: RoHS Compliant; Pricing 1: 1=0.31, 10=0.252, 100=0.1334, 500=0.08772, 1000=0.05976 (EUR); Packaging: Digi-Reel®; Package / Case: 5-TSSOP, SC-70-5, SOT-353; Operating Temperature: -40°C ~ 85°C; Number of Circuits: 1; Mounting Type: Surface Mount; Manufacturer Part Number: NC7SZ04P5X; Logic Type: Inverter; Logic Level - Low: -; Logic Level - High: -; Features: -; ComponentLink1URL: http://www.fairchildsemi.com/datasheets/NC/NC7SZ04.pdf; ComponentLink1Description: http://www.fairchildsemi.com/datasheets/NC/NC7SZ04.pdf; Component Kind: Standard; Category: Integrated Circuits (ICs); Library Name: Skunkworks Library.IntLib
X3 = NX2520SA-32.000000MHZ: CRYSTAL 32.0000MHZ 10PF SMD; Manufacturer: NDK; Operating Mode: Fundamental; Frequency Tolerance: ±10ppm; ESR (Equivalent Series Resistance): 50 Ohm; Value: 32MHz; Type: MHz Crystal; Supplier Part Number 1: 644-1065-6-ND; Supplier 1: Digi-Key; Stock 1: 91241; Size / Dimension: 0.098" L x 0.079" W (2.50mm x 2.00mm); RoHS: RoHS Compliant; Ratings: -; Pricing 1: 1=1.09, 10=1.043, 50=1.02, 100=0.8723, 500=0.84924, 1000=0.71539 (EUR); Packaging: Digi-Reel®; Package / Case: 4-SMD, No Lead (DFN, LCC); Operating Temperature: -10°C ~ 60°C; Mounting Type: Surface Mount; Manufacturer Part Number: NX2520SA-32.000000MHZ; Load Capacitance: 10pF; Height: 0.026" (0.65mm); Frequency Stability: ±10ppm; Frequency: 32MHz; ComponentLink1URL: http://media.digikey.com/pdf/Data%20Sheets/NDK%20PDFs/NX2520SA_16-48MHZ.pdf; ComponentLink1Description: http://media.digikey.com/pdf/Data%20Sheets/NDK%20PDFs/NX2520SA_16-48MHZ.pdf; Component Kind: Standard; Category: Crystals and Oscillators; Library Name: Skunkworks Library.IntLib
